# Altium schematic sheet Sch.SchDoc
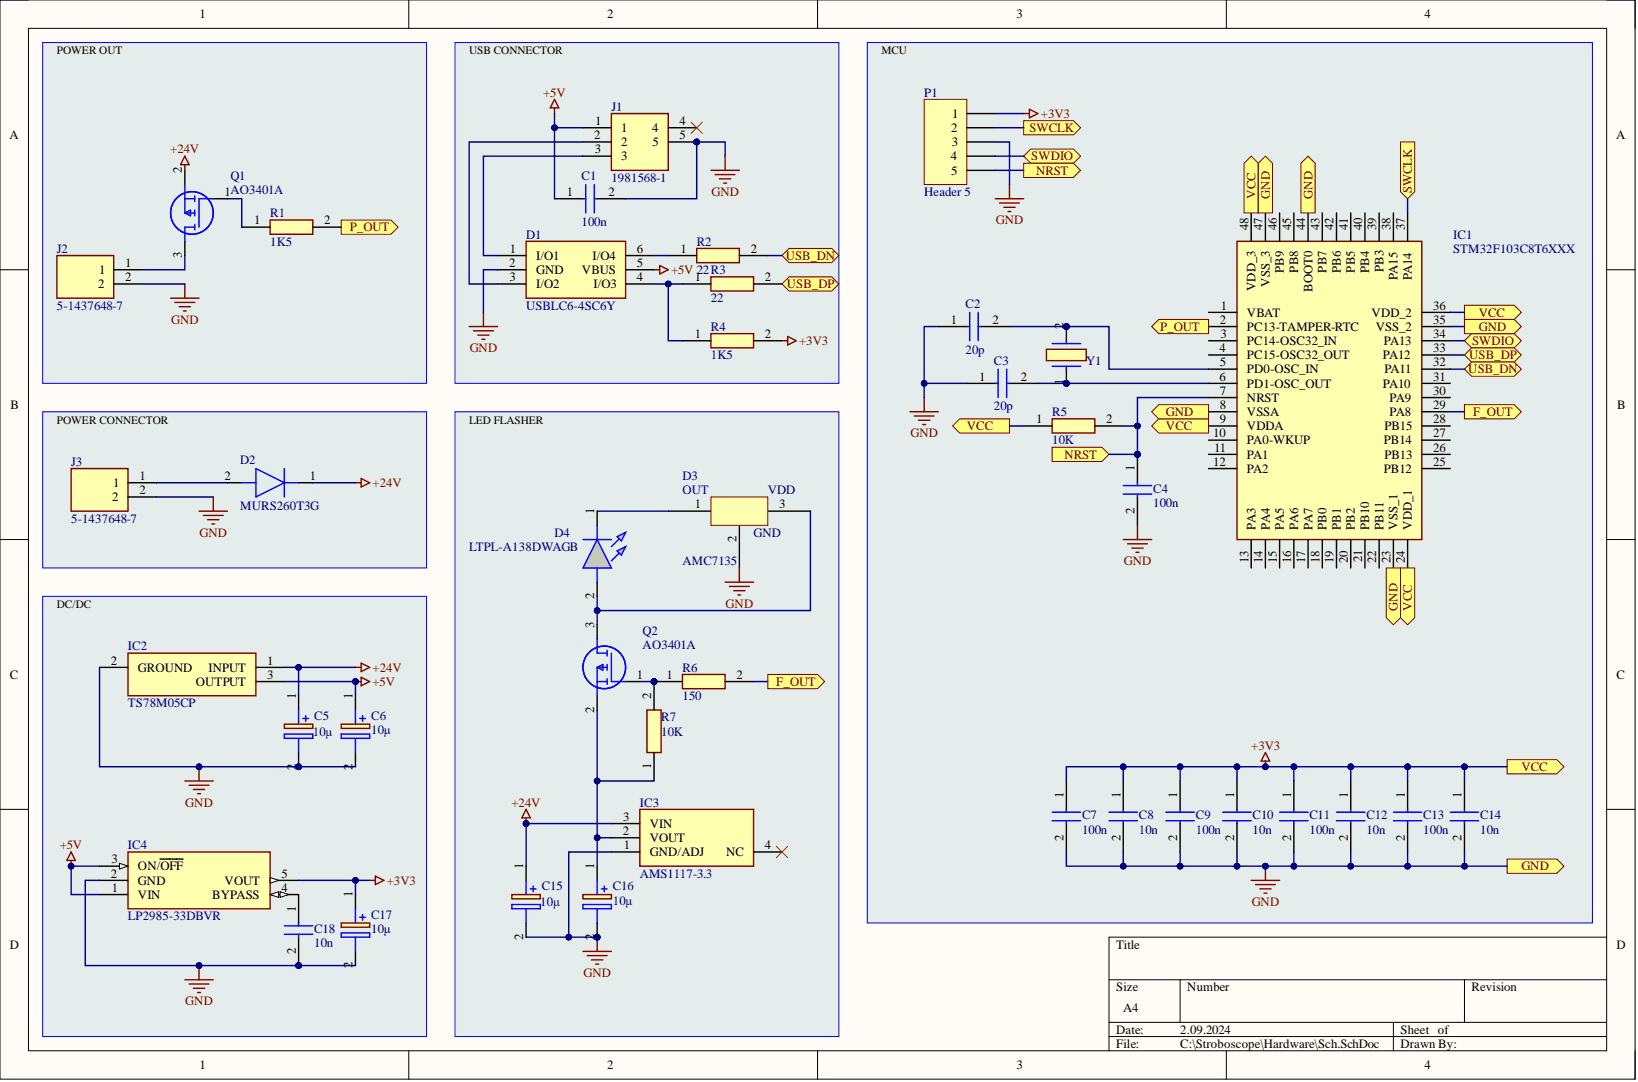
[diagram p0: Sch.SchDoc]

## Parts
C1  100n  [CAPC1608X90N]
C2  20p  [CAPC1608X90N]
C3  20p  [CAPC1608X90N]
C4  100n  [CAPC1608X90N]
C5  10μ  [EEEFK1V331P]
C6  10μ  [EEEFK1V331P]
C7  100n  [CAPC1608X90N]
C8  10n  [CAPC1608X90N]
C9  100n  [CAPC1608X90N]
C10  10n  [CAPC1608X90N]
C11  100n  [CAPC1608X90N]
C12  10n  [CAPC1608X90N]
C13  100n  [CAPC1608X90N]
C14  10n  [CAPC1608X90N]
C15  10μ  [EEEFK1V331P]
C16  10μ  [EEEFK1V331P]
C17  10μ  [EEEFK1V331P]
C18  10n  [CAPC1608X90N]
D1  USBLC6-4SC6Y  [SOT95P280X145-6N]
D2  MURS260T3G  [DIOM5436X247N]
D3  AMC7135
D4  LTPL-A138DWAGB  [LEDC1010X25N]
IC1  STM32F103C8T6XXX  [QFP50P900X900X160-48N]
IC2  TS78M05CP  [TS78M05CP]
IC3  AMS1117-3.3  [SOT229P700X180-4N]
IC4  LP2985-33DBVR  [SOT95P280X145-5N]
J1  1981568-1  [1981568-1]
J2  5-1437648-7  [SHDR2W165P0X826_1X2_1791X1524X1270P]
J3  5-1437648-7  [SHDR2W165P0X826_1X2_1791X1524X1270P]
P1  Header 5  [HDR1X5]
Q1  AO3401A  [SOT95P280X125-3N]
Q2  AO3401A  [SOT95P280X125-3N]
R1  1K5  [RESC1608X55N]
R2  22  [RESC1608X55N]
R3  22  [RESC1608X55N]
R4  1K5  [RESC1608X55N]
R5  10K  [RESC1608X55N]
R6  150  [RESC1608X55N]
R7  10K  [RESC1608X55N]
Y1  HC49SM-8-30-50-60-16-ATF  [HC49SM830506016ATF]  (value not drawn)

## Ports
F_OUT, GND, NRST, P_OUT, SWCLK, SWDIO, USB_DN, USB_DP, VCC

## Nets
+5V: C1.1 C6.1 D1.5(VBUS) IC2.3 IC4.1(VIN) IC4.3(ON/OFF) J1.1
+24V: C5.1 C15.1 D2.1 IC2.1 IC3.3(VIN) Q1.2
+3V3: C7.1 C8.1 C9.1 C10.1 C11.1 C12.1 C13.1 C14.1 C17.1 IC1.9(VDDA) IC1.24(VDD_1) IC1.36(VDD_2) IC1.48(VDD_3) IC4.5(VOUT) P1.1 R4.2 R5.1 ; port VCC
F_OUT: IC1.29(PA8) R6.2 ; port F_OUT
GND: C1.2 C2.1 C3.1 C4.2 C5.2 C6.2 C7.2 C8.2 C9.2 C10.2 C11.2 C12.2 C13.2 C14.2 C15.2 C16.2 C17.2 C18.2 D1.2(GND) D3.2 IC1.8(VSSA) IC1.23(VSS_1) IC1.35(VSS_2) IC1.44(BOOT0) IC1.47(VSS_3) IC2.2 IC3.1(GND/ADJ) IC4.2(GND) J1.5 J2.2 J3.2 P1.3 ; port GND
NRST: C4.1 IC1.7(NRST) P1.5 R5.2 ; port NRST
P_OUT: IC1.2(PC13-TAMPER-RTC) R1.2 ; port P_OUT
SWCLK: IC1.37(PA14) P1.2 ; port SWCLK
SWDIO: IC1.34(PA13) P1.4 ; port SWDIO
USB_DN: IC1.32(PA11) R2.2 ; port USB_DN
USB_DP: IC1.33(PA12) R3.2 ; port USB_DP
NetC2_2: C2.2 IC1.5(PD0-OSC_IN) Y1.2
NetC3_2: C3.2 IC1.6(PD1-OSC_OUT) Y1.1
NetC16_1: C16.1 IC3.2(VOUT) Q2.2 R7.1
NetC18_1: C18.1 IC4.4(BYPASS)
NetD1_1: D1.1(I/O1) J1.3
NetD1_3: D1.3(I/O2) J1.2
NetD1_4: D1.4(I/O3) R3.1 R4.1
NetD1_6: D1.6(I/O4) R2.1
NetD2_2: D2.2 J3.1
NetD3_1: D3.1 D4.1
NetD3_3: D3.3 D4.2 Q2.3
NetJ2_1: J2.1 Q1.3
NetQ1_1: Q1.1 R1.1
NetQ2_1: Q2.1 R6.1 R7.2

Not connected: IC1 1(VBAT) 3(PC14-OSC32_IN) 4(PC15-OSC32_OUT) 10(PA0-WKUP) 11(PA1) 12(PA2) 13(PA3) 14(PA4) 15(PA5) 16(PA6) 17(PA7) 18(PB0) 19(PB1) 20(PB2) 21(PB10) 22(PB11) 25(PB12) 26(PB13) 27(PB14) 28(PB15) 30(PA9) 31(PA10) 38(PA15) 39(PB3) 40(PB4) 41(PB5) 42(PB6) 43(PB7) 45(PB8) 46(PB9); IC3 4(NC); J1 4

## Library data of the parts
C1 C4 C7 C9 C11 C13 = CC0603KRX7R7BB104: Capacitor CC0603KRX7R7BB104; Manufacturer_Name: KEMET; Arrow Part Number: CC0603KRX7R7BB104; Arrow Price/Stock: https://www.arrow.com/en/products/cc0603krx7r7bb104/yageo?region=nac; Component Kind: Standard; Datasheet Link: https://ms.componentsearchengine.com/Datasheets/2/CC0603KRX7R7BB104.pdf; Height: 0.9mm; Manufacturer_Part_Number: CC0603KRX7R7BB104; Mouser Part Number: 603-CC603KRX7R7BB104; Mouser Price/Stock: https://www.mouser.co.uk/ProductDetail/YAGEO/CC0603KRX7R7BB104?qs=AgBp2OyFlx8KPmNTlFoWXA%3D%3D
C2 C3 = GRM1885C1H200JA01J: Capacitor GRM1885C1H200JA01J; Manufacturer_Name: Murata Electronics; Component Kind: Standard; Datasheet Link: http://componentsearchengine.com/Datasheets/1/GRM1885C1H200JA01J.pdf; Height: 0.9mm; Manufacturer_Part_Number: GRM1885C1H200JA01J; Mouser Part Number: 81-GRM185C1H200JA01J; Mouser Price/Stock: https://www.mouser.co.uk/ProductDetail/Murata-Electronics/GRM1885C1H200JA01J?qs=SSucg2PyLi5AT43tSDep3g%3D%3D
C5 C6 C15-C17 = EEH-ZF1H101P: Capacitor Polarised EEH-ZF1H101P; Manufacturer_Name: Panasonic; Arrow Part Number: EEH-ZF1H101P; Arrow Price/Stock: https://www.arrow.com/en/products/eeh-zf1h101p/panasonic?region=nac; Component Kind: Standard; Datasheet Link: https://media.digikey.com/pdf/Data%20Sheets/Panasonic%20Capacitors%20PDFs/EEH-ZF_V_Type_DS.pdf; Manufacturer_Part_Number: EEH-ZF1H101P; Mouser Part Number: 667-EEH-ZF1H101P; Mouser Price/Stock: https://www.mouser.co.uk/ProductDetail/Panasonic/EEH-ZF1H101P?qs=vmHwEFxEFR99%2FtHqmuLJlw%3D%3D
C8 C10 C12 C14 C18 = CC0603KRX7R7BB103: Capacitor CC0603KRX7R7BB103; Manufacturer_Name: KEMET; Arrow Part Number: CC0603KRX7R7BB103; Arrow Price/Stock: https://www.arrow.com/en/products/cc0603krx7r7bb103/yageo?region=nac; Component Kind: Standard; Datasheet Link: https://datasheet.datasheetarchive.com/originals/distributors/Datasheets_SAMA/d9f127a4875522309d99aa7dec79633c.pdf; Height: 0.9mm; Manufacturer_Part_Number: CC0603KRX7R7BB103; Mouser Part Number: 603-CC603KRX7R7BB103; Mouser Price/Stock: https://www.mouser.co.uk/ProductDetail/YAGEO/CC0603KRX7R7BB103?qs=5q%252BqlK8CmJRarFmz5k060w%3D%3D
D1 = USBLC6-4SC6Y: Diode ESD (Uni-directional); Manufacturer_Name: STMicroelectronics; Arrow Part Number: USBLC6-4SC6Y; Arrow Price/Stock: https://www.arrow.com/en/products/usblc6-4sc6y/stmicroelectronics?region=nac; Component Kind: Standard; Datasheet Link: https://www.st.com/resource/en/datasheet/usblc6-4sc6y.pdf; Height: 1.45mm; Manufacturer_Part_Number: USBLC6-4SC6Y; Mouser Part Number: 511-USBLC6-4SC6Y; Mouser Price/Stock: https://www.mouser.co.uk/ProductDetail/STMicroelectronics/USBLC6-4SC6Y?qs=gNDSiZmRJS%252B5K%252Bxjl%252Bw9xw%3D%3D
D2 = MURS260T3G: Diode; Manufacturer_Name: onsemi; Arrow Part Number: MURS260T3G; Arrow Price/Stock: https://www.arrow.com/en/products/murs260t3g/on-semiconductor?region=nac; Component Kind: Standard; Datasheet Link: http://www.onsemi.com/pub/Collateral/MURS260T3-D.PDF; Height: 2.47mm; Manufacturer_Part_Number: MURS260T3G; Mouser Part Number: 863-MURS260T3G; Mouser Price/Stock: https://www.mouser.co.uk/ProductDetail/onsemi/MURS260T3G?qs=Gev%252BmEvV0ib1oIxhXTweLQ%3D%3D
D4 = LTPL-A138DWAGB: High Power LEDs - Single Color Top view High Power LED; Manufacturer_Name: Lite-On; Geometry.Height: 0.25mm; Component Kind: Standard; Datasheet Link: https://www.mouser.com.tr/ProductDetail/Lite-On/LTPL-A138DWAGB?qs=By6Nw2ByBD19OWPRz6%2F8tg%3D%3D&_gl=1*1kqb2vu*_ga*MTYxNzU2NDgwMy4xNjY0MzU4NjYy*_ga_15W4STQT4T*MTcwNjA4MTA4NS4xNS4wLjE3MDYwODEwODYuNTkuMC4w*_ga_1KQLCYKRX3*MTcwNjA4MTA4Ni43LjAuMTcwNjA4MTA4Ni4w; Library Name: LTPL-A138DWAGB.SchLib; Manufacturer_Part_Number: LTPL-A138DWAGB
IC1 = STM32F103C8T6XXX: Integrated Circuit; Manufacturer_Name: ST; Component Kind: Standard; Height: 1.6mm; Manufacturer_Part_Number: STM32F103C8T6XXX
IC2 = TS78M05CP: Integrated Circuit; Manufacturer_Name: Taiwan Semiconductor; Component Kind: Standard; Datasheet Link: https://componentsearchengine.com/Datasheets/1/TS78M05CP.pdf; Height: 2.38mm; Manufacturer_Part_Number: TS78M05CP; Mouser Part Number: 821-TS78M05CP; Mouser Price/Stock: https://www.mouser.co.uk/ProductDetail/Taiwan-Semiconductor/TS78M05CP?qs=F0bBuU9YDEzJZsmmDzcbEA%3D%3D
IC3 = AMS1117-3.3: Integrated Circuit; Manufacturer_Name: Advanced; Component Kind: Standard; Datasheet Link: https://datasheet.datasheetarchive.com/originals/distributors/Datasheets-28/DSA-550594.pdf; Height: 1.8mm; Manufacturer_Part_Number: AMS1117-3.3
IC4 = LP2985-33DBVR: Integrated Circuit; Manufacturer_Name: Texas Instruments; Arrow Part Number: LP2985-33DBVR; Arrow Price/Stock: https://www.arrow.com/en/products/lp2985-33dbvr/texas-instruments?region=nac; Component Kind: Standard; Datasheet Link: http://www.ti.com/lit/gpn/lp2985; Height: 1.45mm; Manufacturer_Part_Number: LP2985-33DBVR; Mouser Part Number: 595-LP2985-33DBVR; Mouser Price/Stock: https://www.mouser.co.uk/ProductDetail/Texas-Instruments/LP2985-33DBVR?qs=paYhMW8qfiswyUdMjD%2FxlQ%3D%3D
J1 = 1981568-1: Connector; Manufacturer_Name: TE Connectivity; Arrow Part Number: 1981568-1; Arrow Price/Stock: https://www.arrow.com/en/products/1981568-1/te-connectivity?region=europe; Component Kind: Standard; Datasheet Link: http://www.te.com/commerce/DocumentDelivery/DDEController?Action=srchrtrv&DocNm=1981568&DocType=Customer+Drawing&DocLang=English; Manufacturer_Part_Number: 1981568-1; Mouser Part Number: 571-1981568-1; Mouser Price/Stock: https://www.mouser.co.uk/ProductDetail/TE-Connectivity/1981568-1?qs=zxTme0yW%2FbaAfvOKTKC5hw%3D%3D
J2 J3 = 5-1437648-7: Connector; Manufacturer_Name: TE Connectivity; Arrow Part Number: 5-1437648-7; Arrow Price/Stock: https://www.arrow.com/en/products/5-1437648-7/te-connectivity; Component Kind: Standard; Datasheet Link: https://www.te.com/commerce/DocumentDelivery/DDEController?Action=showdoc&DocId=Customer+Drawing%7F5-1437648-7%7FL4%7Fpdf%7FEnglish%7FENG_CD_5-1437648-7_L4.pdf%7F5-1437648-7; Height: 12.7mm; Manufacturer_Part_Number: 5-1437648-7
P1 = Header 5: Header, 5-Pin; Component Kind: Standard; LatestRevisionDate: 17-Jul-2002; LatestRevisionNote: Re-released for DXP Platform.; Library Name: Miscellaneous Connectors.IntLib; Signal Integrity: Connector; Publisher: Altium Limited
Q1 Q2 = AO3401A: MOSFET (P-Channel); Manufacturer_Name: Alpha & Omega Semiconductors; Arrow Part Number: AO3401A; Arrow Price/Stock: https://www.arrow.com/en/products/ao3401a/alpha-and-omega-semiconductor?region=nac; Component Kind: Standard; Datasheet Link: http://www.aosmd.com/pdfs/datasheet/AO3401A.pdf; Height: 1.25mm; Manufacturer_Part_Number: AO3401A
R1 R4 = RC0603FR-071K5L: Resistor RC0603FR-071K5L; Manufacturer_Name: YAGEO; Arrow Part Number: RC0603FR-071K5L; Arrow Price/Stock: https://www.arrow.com/en/products/rc0603fr-071k5l/yageo?region=nac; Component Kind: Standard; Datasheet Link: https://www.yageo.com/upload/media/product/productsearch/datasheet/rchip/PYu-RC_Group_51_RoHS_L_12.pdf; Height: 0.55mm; Manufacturer_Part_Number: RC0603FR-071K5L; Mouser Part Number: 603-RC0603FR-071K5L; Mouser Price/Stock: https://www.mouser.co.uk/ProductDetail/YAGEO/RC0603FR-071K5L?qs=VU8sRB4EgwBMt9nc4XGNgA%3D%3D
R2 R3 = RC0603FR-0722RL: Resistor RC0603FR-0722RL; Manufacturer_Name: YAGEO; Arrow Part Number: RC0603FR-0722RL; Arrow Price/Stock: https://www.arrow.com/en/products/rc0603fr-0722rl/yageo?region=nac; Component Kind: Standard; Datasheet Link: http://www.farnell.com/datasheets/1868787.pdf; Height: 0.55mm; Manufacturer_Part_Number: RC0603FR-0722RL; Mouser Part Number: 603-RC0603FR-0722RL; Mouser Price/Stock: https://www.mouser.co.uk/ProductDetail/YAGEO/RC0603FR-0722RL?qs=diQw95jMAeMOsxb8bne7fg%3D%3D
R5 R7 = RC0603FR-0710KL: Resistor RC0603FR-0710KL; Manufacturer_Name: YAGEO; Arrow Part Number: RC0603FR-0710KL; Arrow Price/Stock: https://www.arrow.com/en/products/rc0603fr-0710kl/yageo?region=europe; Component Kind: Standard; Datasheet Link: https://www.mouser.in/datasheet/2/447/PYu_RC_Group_51_RoHS_L_11-1984063.pdf; Height: 0.55mm; Manufacturer_Part_Number: RC0603FR-0710KL; Mouser Part Number: 603-RC0603FR-0710KL; Mouser Price/Stock: https://www.mouser.co.uk/ProductDetail/YAGEO/RC0603FR-0710KL?qs=grNVn54RoB%252B3GtjbJj3wJQ%3D%3D
R6 = RC0603FR-0710KL: Resistor RC0603FR-07150L; Manufacturer_Name: YAGEO; Arrow Part Number: RC0603FR-0710KL; Arrow Price/Stock: https://www.arrow.com/en/products/rc0603fr-0710kl/yageo?region=europe; Component Kind: Standard; Datasheet Link: https://www.mouser.in/datasheet/2/447/PYu_RC_Group_51_RoHS_L_11-1984063.pdf; Height: 0.55mm; Manufacturer_Part_Number: RC0603FR-0710KL; Mouser Part Number: 603-RC0603FR-0710KL; Mouser Price/Stock: https://www.mouser.co.uk/ProductDetail/YAGEO/RC0603FR-0710KL?qs=grNVn54RoB%252B3GtjbJj3wJQ%3D%3D
Y1 = HC49SM-8-30-50-60-16-ATF: Crystal or Oscillator; Manufacturer_Name: Multicomp Pro; Component Kind: Standard; Datasheet Link: http://www.farnell.com/datasheets/1661828.pdf; Height: 4mm; Manufacturer_Part_Number: HC49SM-8-30-50-60-16-ATF
D3 = AMC7135: Component Kind: Standard; Library Name: SamacSys.SchLib
